# Altium schematic sheet Sheet1.SchDoc
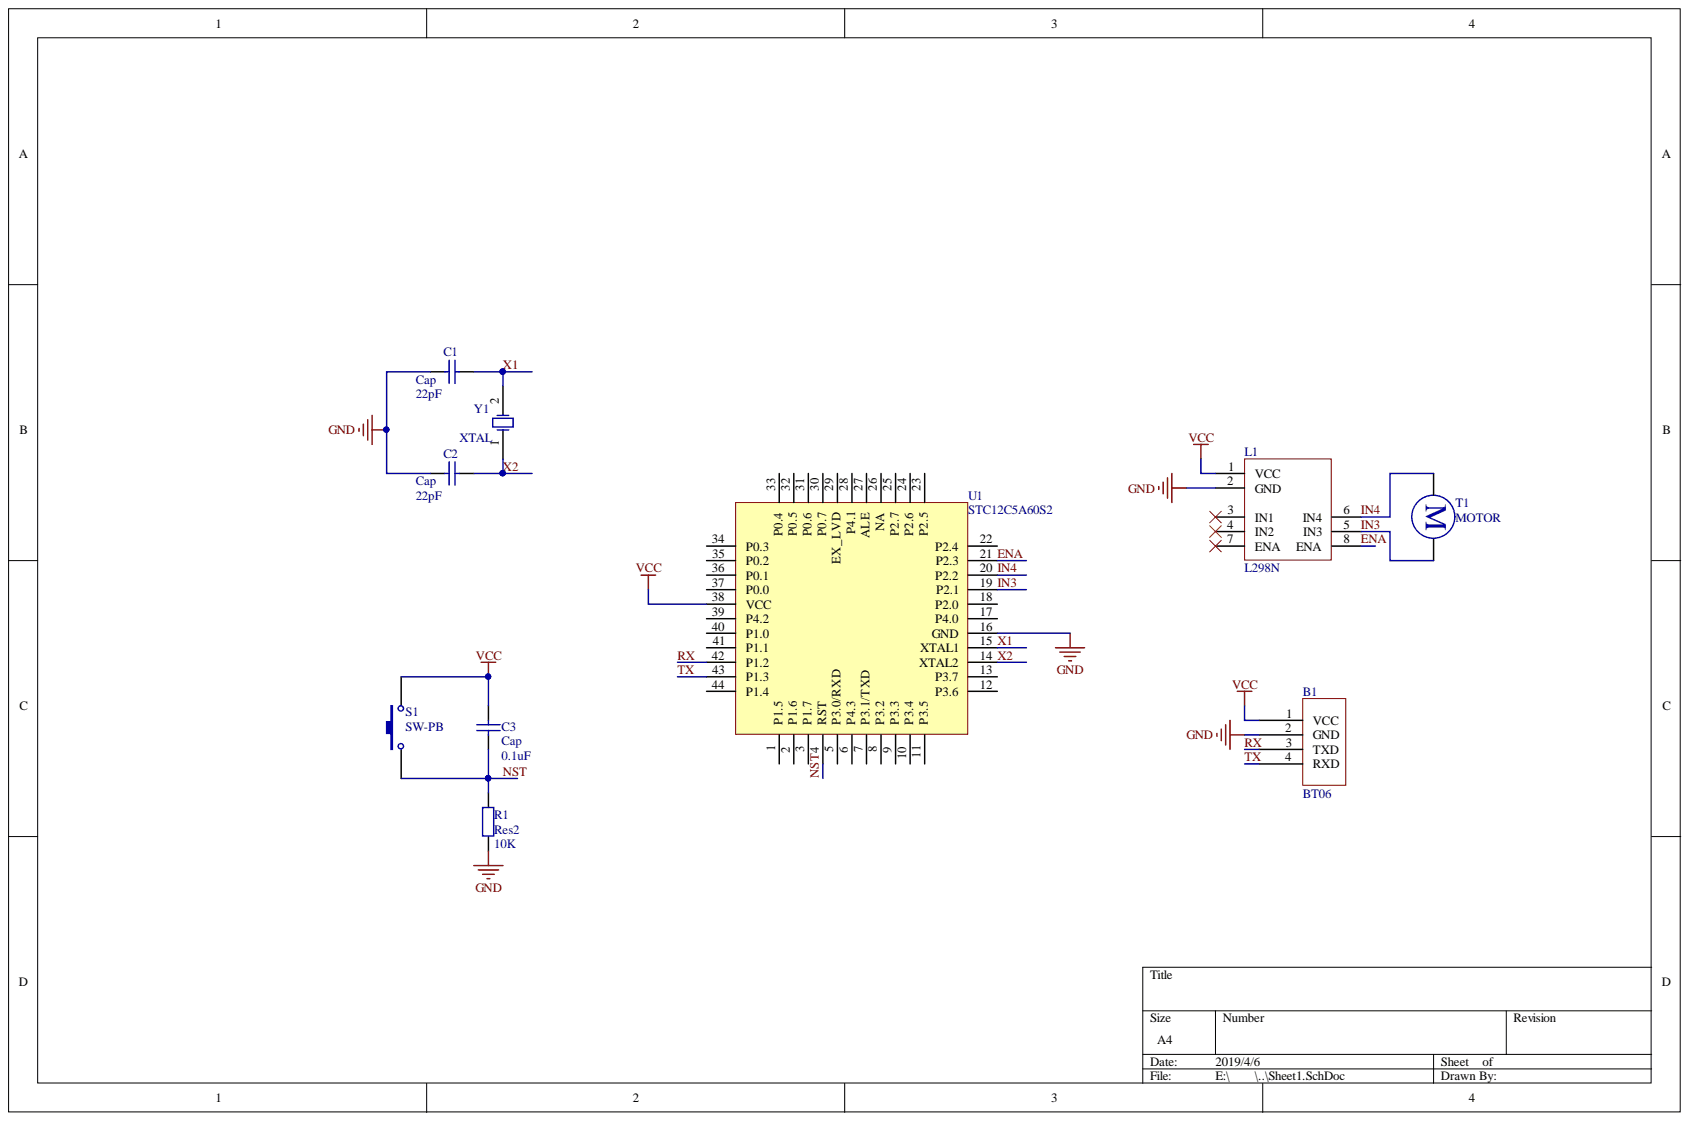
[diagram p0: Sheet1.SchDoc]

## Parts
B1  BT06
C1  Cap  value 22pF  [RAD-0.3]
C2  Cap  value 22pF  [RAD-0.3]
C3  Cap  value 0.1uF  [RAD-0.3]
L1  L298N
R1  Res2  value 10K  [AXIAL-0.4]
S1  SW-PB  [SPST-2]
T1  MOTOR
U1  STC12C5A60S2  [TQFP-44]
Y1  XTAL  [R38]

## Nets
GND: B1.2(GND) C1.1 C2.1 L1.2(GND) R1.1 U1.16(GND)
VCC: B1.1(VCC) C3.2 L1.1(VCC) S1.2 U1.38(VCC)
ENA: L1.8(ENA) U1.21(P2.3)
IN3: L1.5(IN3) T1.2 U1.19(P2.1)
IN4: L1.6(IN4) T1.1 U1.20(P2.2)
NST: C3.1 R1.2 S1.1 U1.4(RST)
RX: B1.3(TXD) U1.42(P1.2)
TX: B1.4(RXD) U1.43(P1.3)
X1: C1.2 U1.15(XTAL1) Y1.2
X2: C2.2 U1.14(XTAL2) Y1.1

Not connected: L1 3(IN1) 4(IN2) 7(ENA); U1 1(P1.5) 2(P1.6) 3(P1.7) 5(P3.0/RXD) 6(P4.3) 7(P3.1/TXD) 8(P3.2) 9(P3.3) 10(P3.4) 11(P3.5) 12(P3.6) 13(P3.7) 17(P4.0) 18(P2.0) 22(P2.4) 23(P2.5) 24(P2.6) 25(P2.7) 26(NA) 27(ALE) 28(P4.1) 29(EX_LVD) 30(P0.7) 31(P0.6) 32(P0.5) 33(P0.4) 34(P0.3) 35(P0.2) 36(P0.1) 37(P0.0) 39(P4.2) 40(P1.0) 41(P1.1) 44(P1.4)

## Library data of the parts
C1-C3 = Cap: Capacitor; Component Kind: Standard; LatestRevisionDate: 17-Jul-2002; LatestRevisionNote: Re-released for DXP Platform.; Library Name: Miscellaneous Devices.IntLib; PackageDescription: Radial Cap, Thru-Hole; 2 Leads; 0.3 in Pin Spacing; PackageReference: RAD-0.3; Published: 8-Jun-2000; Signal Integrity: Cap; Simulation: CAP; Publisher: Altium Limited
R1 = Res2: Resistor; Component Kind: Standard; LatestRevisionDate: 17-Jul-2002; LatestRevisionNote: Re-released for DXP Platform.; Library Name: Miscellaneous Devices.IntLib; PackageDescription: Axial Device, Thru-Hole; 2 Leads; 0.4 in Pin Spacing; PackageReference: AXIAL-0.4; Published: 8-Jun-2000; Signal Integrity: Res; Simulation: RESISTOR; Publisher: Altium Limited
S1 = SW-PB: Switch; Component Kind: Standard; LatestRevisionDate: 17-Jul-2002; LatestRevisionNote: Re-released for DXP Platform.; Library Name: Miscellaneous Devices.IntLib; PackageDescription: Switch; 2 Leads; PackageReference: SPST-2; Published: 24-Mar-1999; Publisher: Altium Limited
Y1 = XTAL: Crystal Oscillator; Component Kind: Standard; LatestRevisionDate: 17-Jul-2002; LatestRevisionNote: Re-released for DXP Platform.; Library Name: Miscellaneous Devices.IntLib; PackageDescription: Crystal, Thru-Hole; 2 Leads; Body 3.1 x 8.2 mm (Dia.xH); PackageReference: R38; Published: 8-Jun-2000; Simulation: XTAL; Publisher: Altium Limited
B1 = BT06: Component Kind: Standard; Library Name: mine.SchLib
L1 = L298N: Component Kind: Standard; Library Name: mine.SchLib
T1 = MOTOR: Component Kind: Standard; Library Name: 常用器件.SCHLIB
U1 = STC12C5A60S2: Component Kind: Standard; Library Name: STC12C5A60.SchLib
